# Altium schematic sheet FINAL_VERSION.SchDoc(FINAL_VERSION)
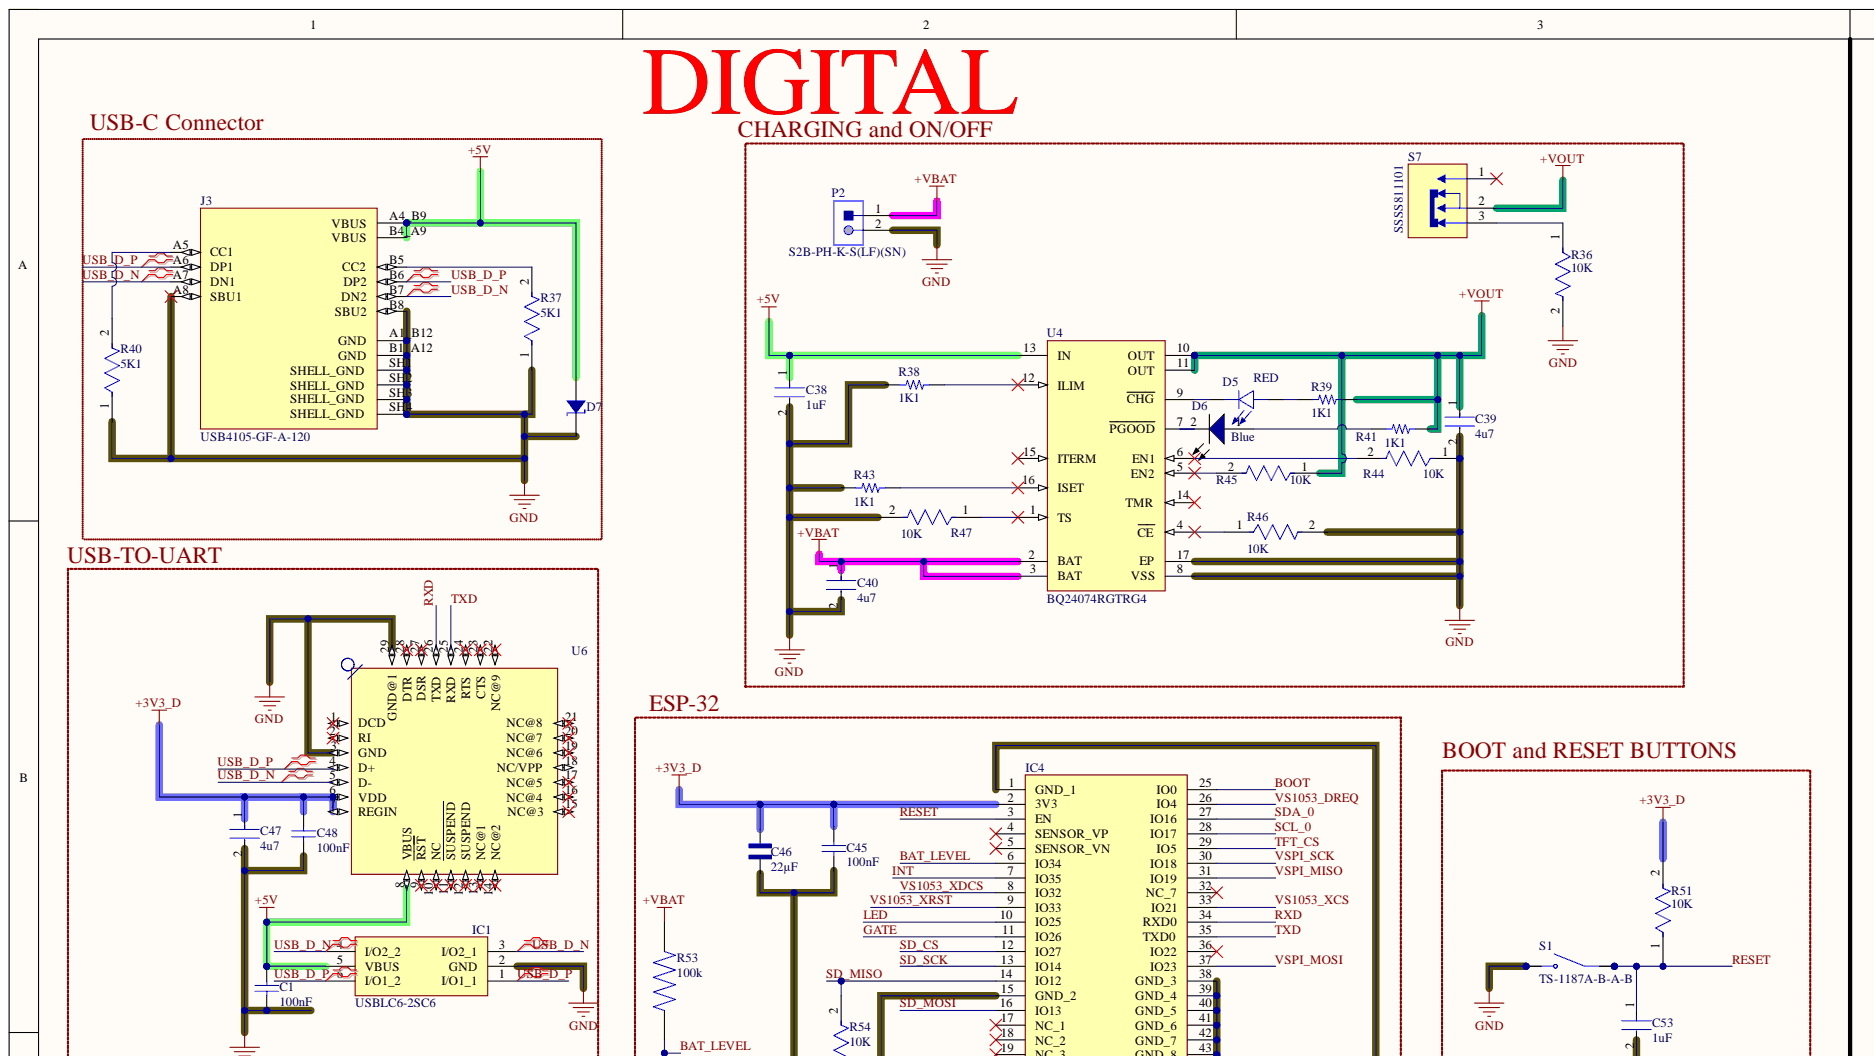
[diagram p0: FINAL_VERSION.SchDoc, top left]
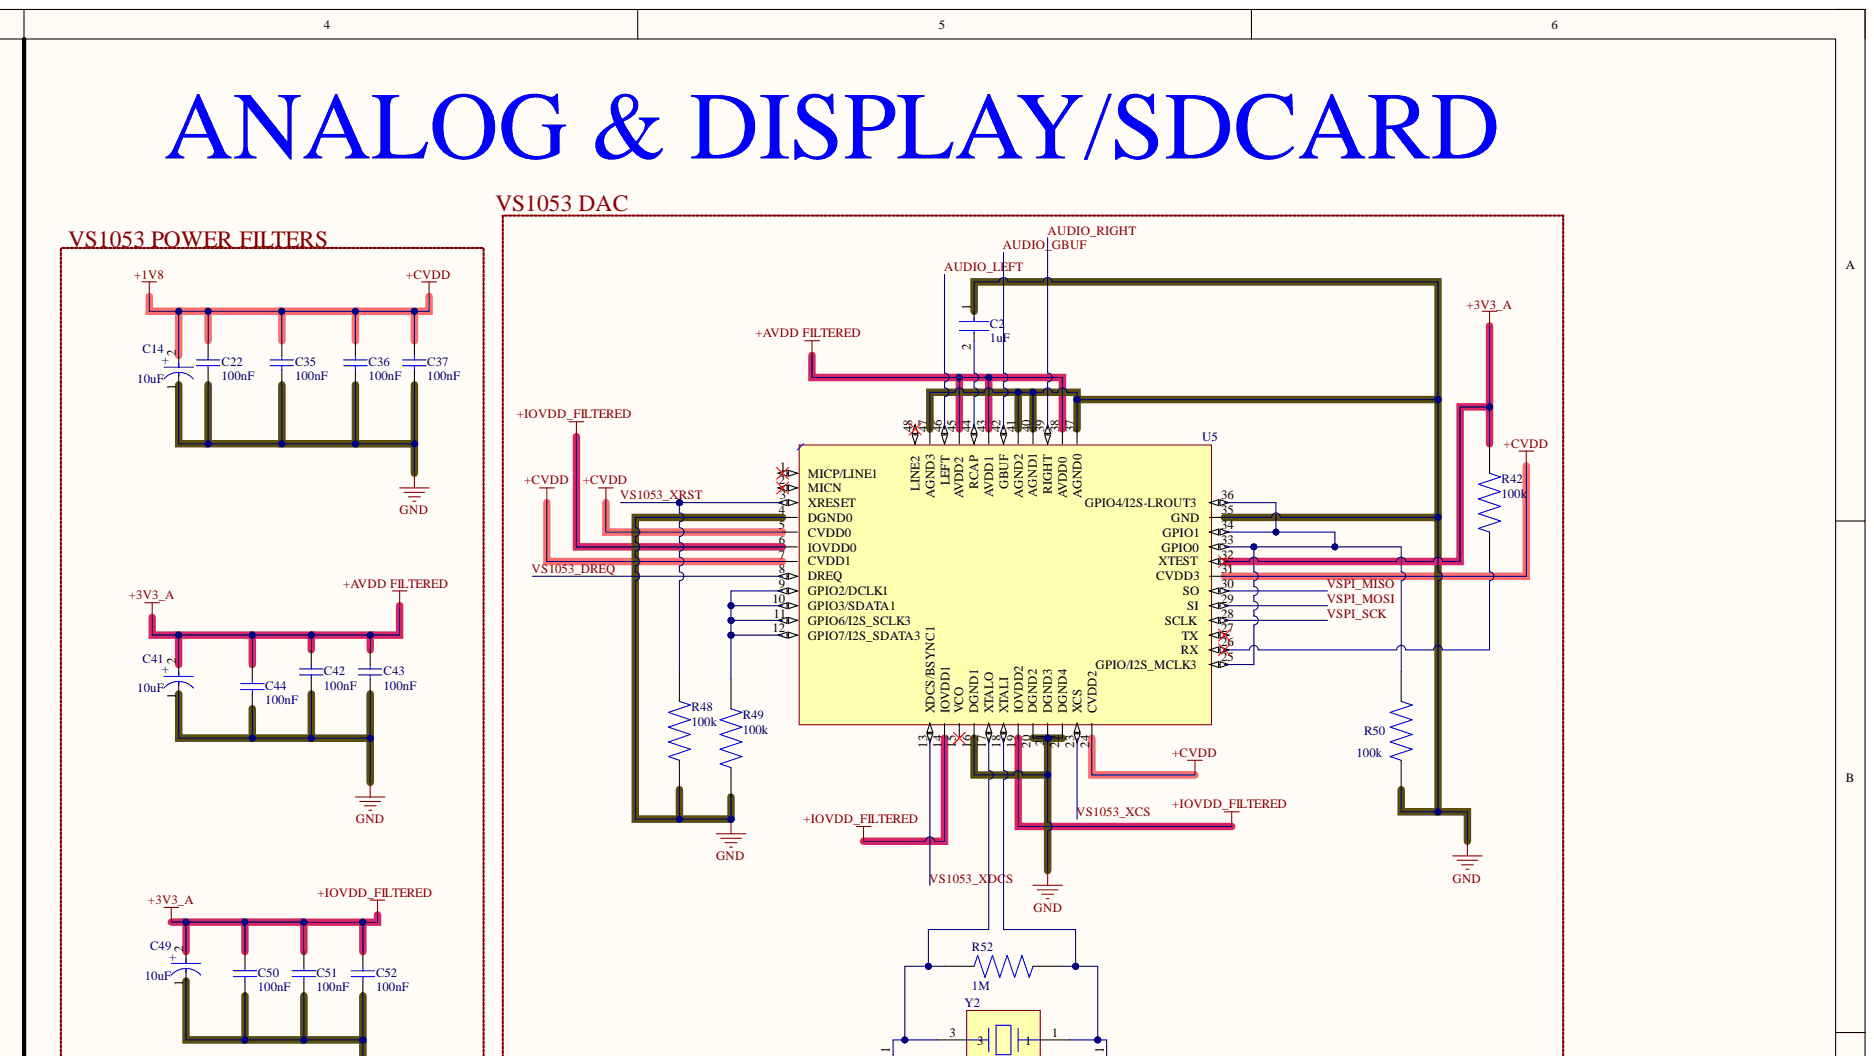
[diagram p1: FINAL_VERSION.SchDoc, top right]
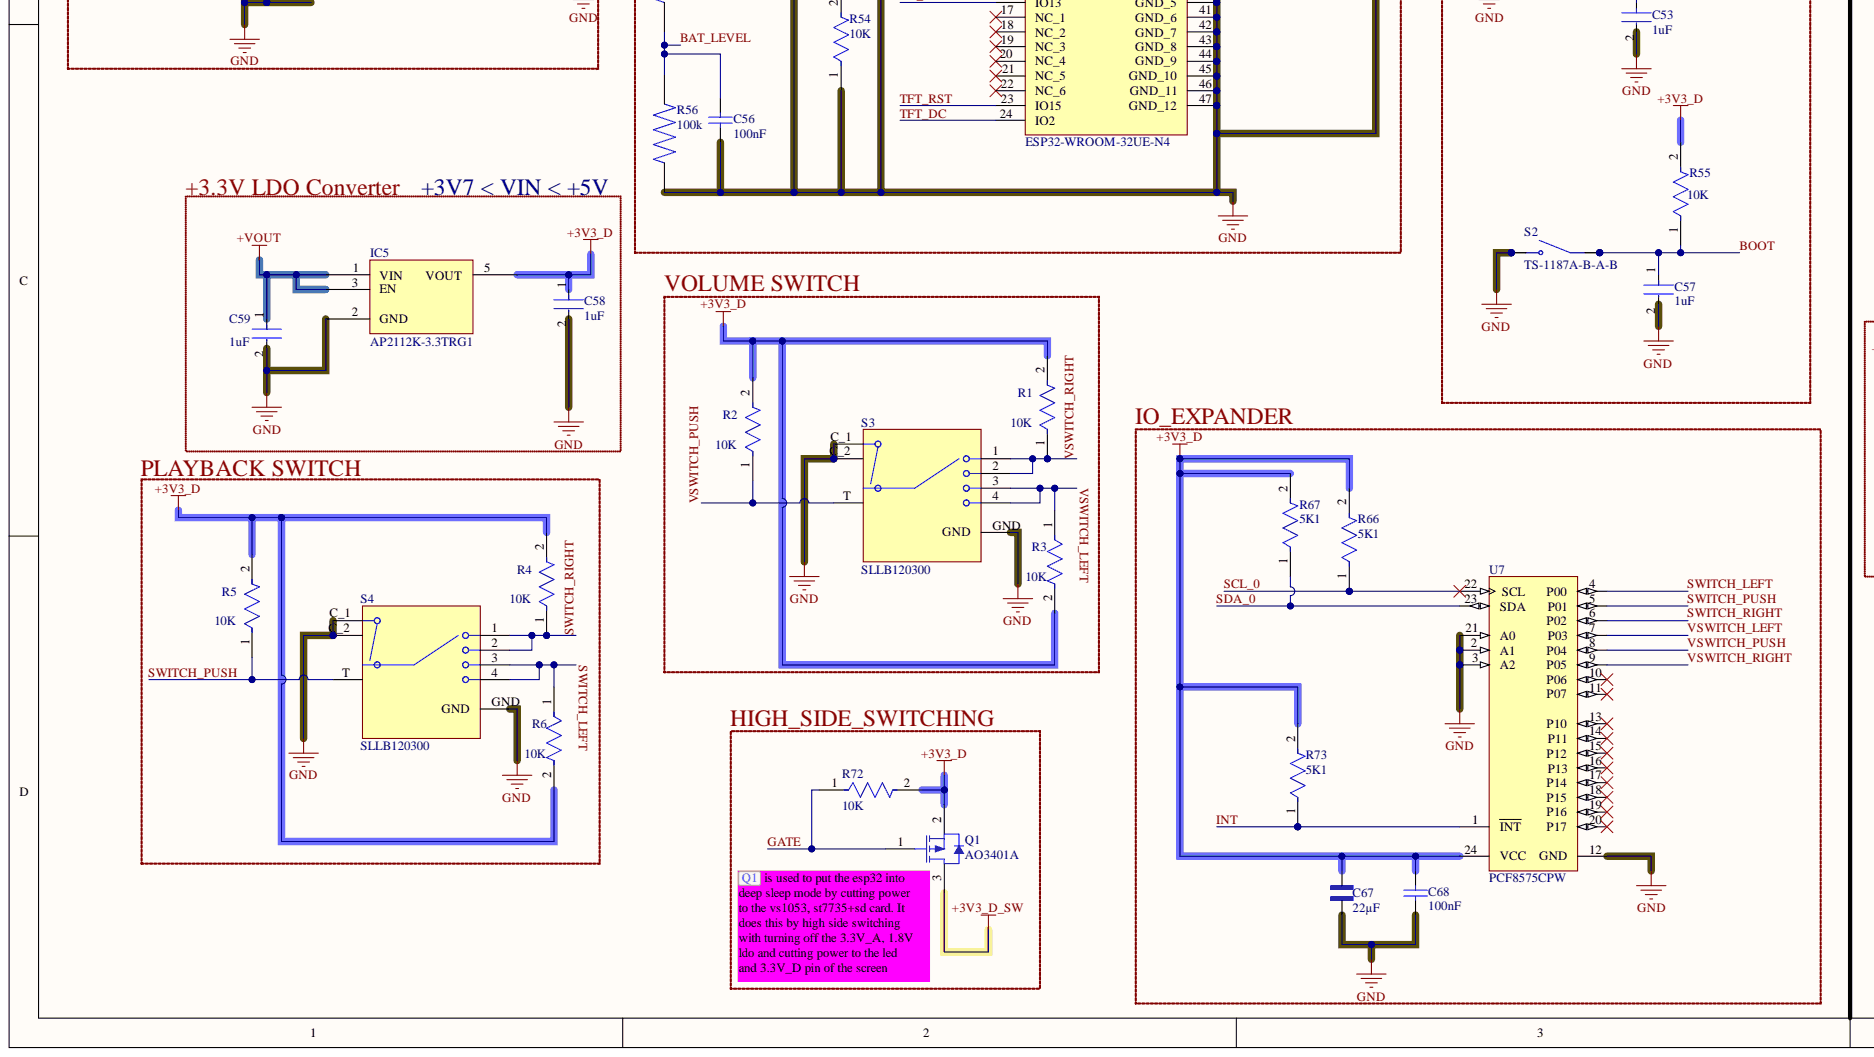
[diagram p2: FINAL_VERSION.SchDoc, bottom left]
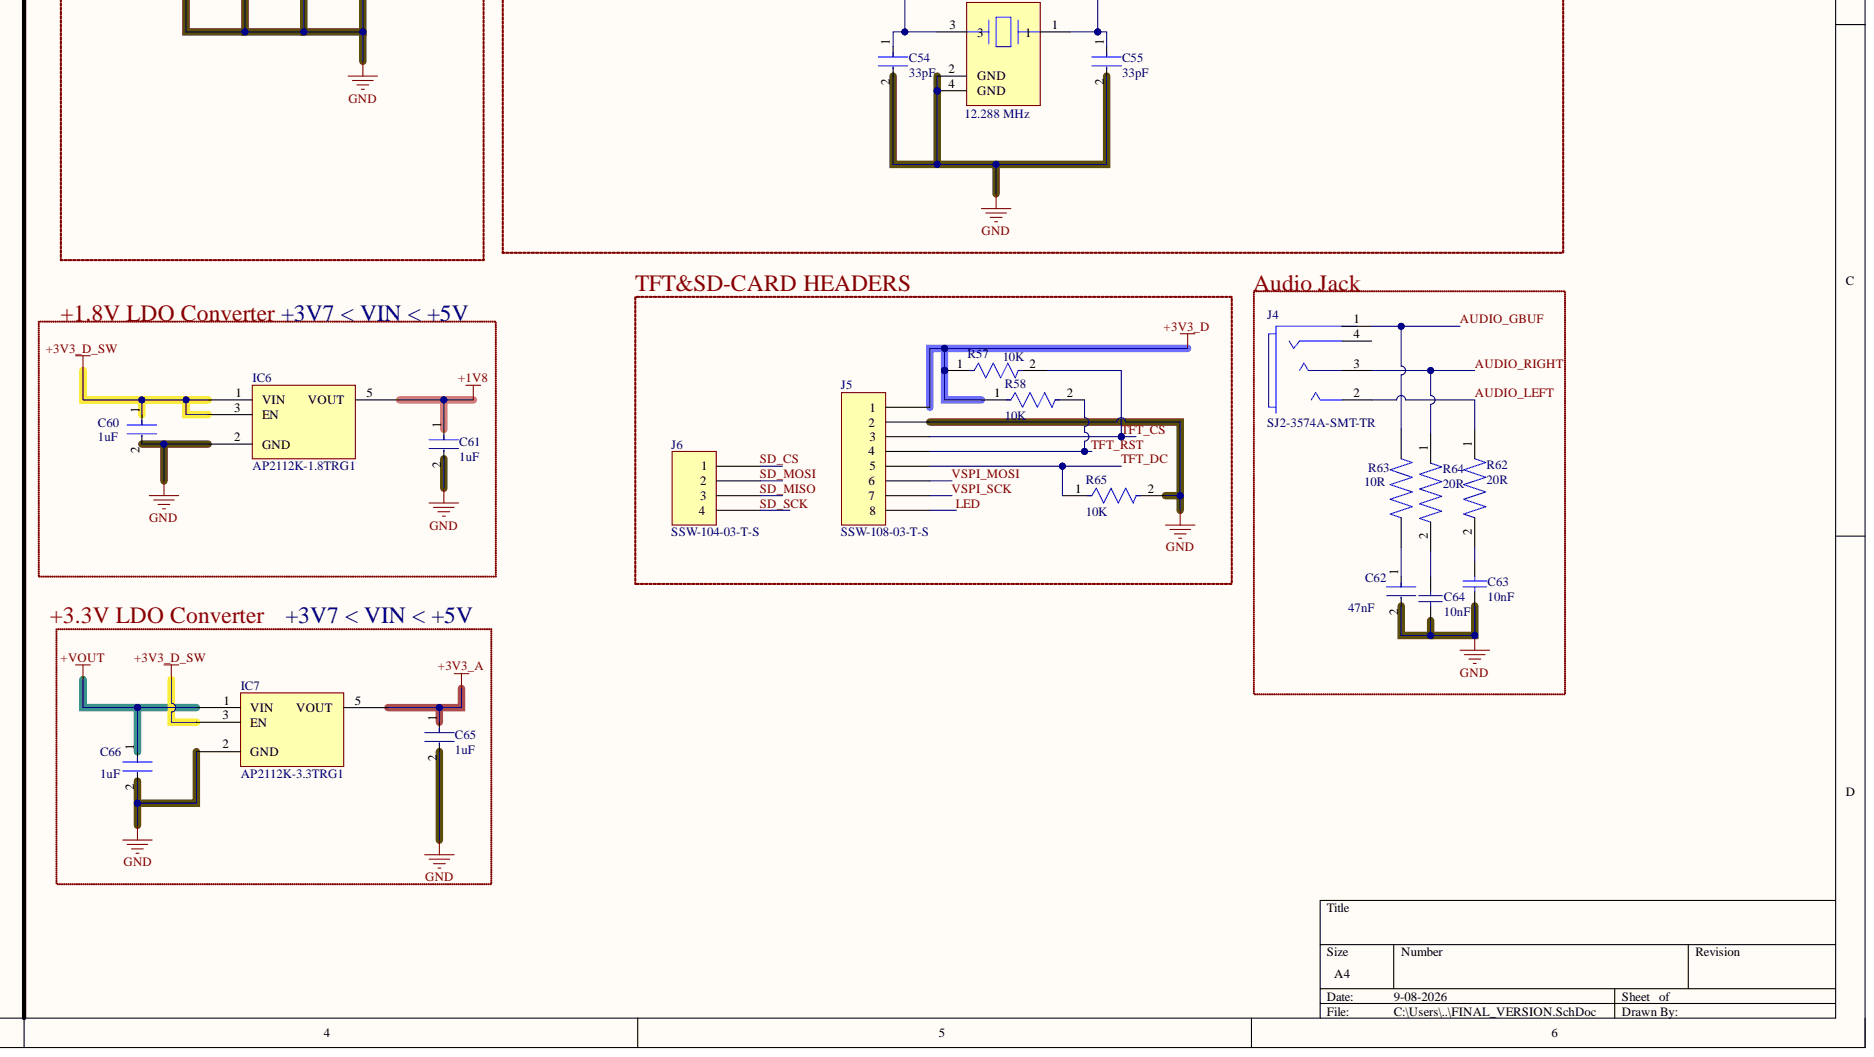
[diagram p3: FINAL_VERSION.SchDoc, bottom right]

## Parts
C1  100nF  [CAPC1005X55X25ML05T10]
C2  1uF  [FP-CL511-IPC_C]
C14  10uF  [FP-TAJA-MFG]
C22  100nF  [CAPC1005X55X25ML05T10]
C35  100nF  [CAPC1005X55X25ML05T10]
C36  100nF  [CAPC1005X55X25ML05T10]
C37  100nF  [CAPC1005X55X25ML05T10]
C38  1uF  [FP-CL511-IPC_C]
C39  4u7  [FP-CL282-IPC_C]
C40  4u7  [FP-CL282-IPC_C]
C41  10uF  [FP-TAJA-MFG]
C42  100nF  [CAPC1005X55X25ML05T10]
C43  100nF  [CAPC1005X55X25ML05T10]
C44  100nF  [CAPC1005X55X25ML05T10]
C45  100nF  [CAPC1005X55X25ML05T10]
C46  value 22µF  [CAPC1608X100N]  (hidden comment: CL10A226MQ8NRNC)
C47  4u7  [FP-CL282-IPC_C]
C48  100nF  [CAPC1005X55X25ML05T10]
C49  10uF  [FP-TAJA-MFG]
C50  100nF  [CAPC1005X55X25ML05T10]
C51  100nF  [CAPC1005X55X25ML05T10]
C52  100nF  [CAPC1005X55X25ML05T10]
C53  1uF  [FP-CL511-IPC_C]
C54  33pF  [FP-CL790-IPC_C]
C55  33pF  [FP-CL790-IPC_C]
C56  100nF  [CAPC1005X55X25ML05T10]
C57  1uF  [FP-CL511-IPC_C]
C58  1uF  [FP-CL511-IPC_C]
C59  1uF  [FP-CL511-IPC_C]
C60  1uF  [FP-CL511-IPC_C]
C61  1uF  [FP-CL511-IPC_C]
C62  47nF  [FP-CL10-IPC_A]
C63  10nF  [CAPC1005X55X25LL05T05]
C64  10nF  [CAPC1005X55X25LL05T05]
C65  1uF  [FP-CL511-IPC_C]
C66  1uF  [FP-CL511-IPC_C]
C67  value 22µF  [CAPC1608X100N]  (hidden comment: CL10A226MQ8NRNC)
C68  100nF  [CAPC1005X55X25ML05T10]
D5  RED  [LED_KT-0603R]
D6  Blue  [LTST-C190TBKT-2_V]
D7  (no value)  [SMF DO-219AB]
IC1  USBLC6-2SC6  [SOT95P280X145-6N]
IC4  ESP32-WROOM-32UE-N4  [ESP32WROOM32UEN4]
IC5  AP2112K-3.3TRG1  [DIODES SOT-23-5 W5]
IC6  AP2112K-1.8TRG1  [DIODES SOT-23-5 W5]
IC7  AP2112K-3.3TRG1  [DIODES SOT-23-5 W5]
J3  USB4105-GF-A-120  [GCT_USB4105-GF-A-120]
J4  SJ2-3574A-SMT-TR  [FP-SJ2-3574A-SMT-TR-MFG]
J5  SSW-108-03-T-S  [SAMTEC SSW-108-03-T-S]
J6  SSW-104-03-T-S  [SAMTEC SSW-104-03-T-S]
P2  S2B-PH-K-S(LF)(SN)  [JST-S2B-PH-K-S_V]
Q1  AO3401A  [AO-SOT-23-3L_V]
R1  10K  [FP-CRCW0402-e3-IPC_B]
R2  10K  [FP-CRCW0402-e3-IPC_B]
R3  10K  [FP-CRCW0402-e3-IPC_B]
R4  10K  [FP-CRCW0402-e3-IPC_B]
R5  10K  [FP-CRCW0402-e3-IPC_B]
R6  10K  [FP-CRCW0402-e3-IPC_B]
R36  10K  [FP-CRCW0402-e3-IPC_B]
R37  5K1  [FP-CRCW0402-e3-IPC_C]
R38  1K1  [RES 0603_1608]
R39  1K1  [RES 0603_1608]
R40  5K1  [FP-CRCW0402-e3-IPC_C]
R41  1K1  [RES 0603_1608]
R42  value 100k  [RESC1005X40N]  (hidden comment: 0402WGF1003TCE)
R43  1K1  [RES 0603_1608]
R44  10K  [FP-CRCW0402-e3-IPC_B]
R45  10K  [FP-CRCW0402-e3-IPC_B]
R46  10K  [FP-CRCW0402-e3-IPC_B]
R47  10K  [FP-CRCW0402-e3-IPC_B]
R48  value 100k  [RESC1005X40N]  (hidden comment: 0402WGF1003TCE)
R49  value 100k  [RESC1005X40N]  (hidden comment: 0402WGF1003TCE)
R50  value 100k  [RESC1005X40N]  (hidden comment: 0402WGF1003TCE)
R51  10K  [FP-CRCW0402-e3-IPC_B]
R52  value 1M  [RESC1005X40N]  (hidden comment: CRCW04021M00FKEDHP)
R53  value 100k  [RESC1005X40N]  (hidden comment: 0402WGF1003TCE)
R54  10K  [FP-CRCW0402-e3-IPC_B]
R55  10K  [FP-CRCW0402-e3-IPC_B]
R56  value 100k  [RESC1005X40N]  (hidden comment: 0402WGF1003TCE)
R57  10K  [FP-CRCW0402-e3-IPC_B]
R58  10K  [FP-CRCW0402-e3-IPC_B]
R62  value 20R  [RESC1608X55N]  (hidden comment: 0603WAF200JT5E)
R63  value 10R  [RESC1005X40N]  (hidden comment: 0402WGF100JTCE)
R64  value 20R  [RESC1608X55N]  (hidden comment: 0603WAF200JT5E)
R65  10K  [FP-CRCW0402-e3-IPC_B]
R66  5K1  [FP-CRCW0402-e3-IPC_C]
R67  5K1  [FP-CRCW0402-e3-IPC_C]
R72  10K  [FP-CRCW0402-e3-IPC_B]
R73  5K1  [FP-CRCW0402-e3-IPC_C]
S1  TS-1187A-B-A-B  [SW_TS-1187A-B-A-B]
S2  TS-1187A-B-A-B  [SW_TS-1187A-B-A-B]
S3  SLLB120300  [SW_SLLB120300]
S4  SLLB120300  [SW_SLLB120300]
S7  SSSS811101  [SW_SSSS811101]
U4  BQ24074RGTRG4  [RGT16-1700X1700TP]
U5  (no value)  [LQFP48-0.5-7X7MM]
U6  (no value)  [QFN28G_0.5-5X5MM]
U7  PCF8575CPW  [PW0024A_N]
Y2  12.288 MHz  [FP-ABM8G-MFG]

## Nets
+1V8: C14.2 C22.2 C35.2 C36.2 C37.2 C61.1 IC6.5(VOUT) U5.5(CVDD0) U5.7(CVDD1) U5.24(CVDD2) U5.31(CVDD3)
+3V3_A: C41.2 C42.2 C43.2 C44.2 C49.2 C50.2 C51.2 C52.2 C65.1 IC7.5(VOUT) R42.2 U5.6(IOVDD0) U5.14(IOVDD1) U5.19(IOVDD2) U5.32(XTEST) U5.38 U5.43(AVDD1) U5.45(AVDD2)
+3V3_D: C45.2 C46.2 C47.1 C48.2 C58.1 C67.2 C68.2 IC4.2(3V3) IC5.5(VOUT) J5.1 Q1.2 R1.2 R2.2 R3.2 R4.2 R5.2 R6.2 R51.2 R55.2 R57.1 R58.1 R66.2 R67.2 R72.2 R73.2 U6.6(VDD) U6.7(REGIN) U7.24(VCC)
+3V3_D_SW: C60.1 IC6.1(VIN) IC6.3(EN) IC7.3(EN) Q1.3
+5V: C1.2 C38.1 D7.1 IC1.5(VBUS) J3.A4_B9(VBUS) J3.B4_A9(VBUS) U4.13(IN) U6.8(VBUS)
+VBAT: C40.1 P2.1 R53.2 U4.2(BAT) U4.3(BAT)
+VOUT: C39.1 C59.1 C66.1 IC5.1(VIN) IC5.3(EN) IC7.1(VIN) R39.2 R41.2 R45.1 S7.2 U4.10(OUT) U4.11(OUT)
GND: C1.1 C2.1 C14.1 C22.1 C35.1 C36.1 C37.1 C38.2 C39.2 C40.2 C41.1 C42.1 C43.1 C44.1 C45.1 C46.1 C47.2 C48.1 C49.1 C50.1 C51.1 C52.1 C53.2 C54.2 C55.2 C56.1 C57.2 C58.2 C59.2 C60.2 C61.2 C62.2 C63.1 C64.1 C65.2 C66.2 C67.1 C68.1 D7.2 IC1.2(GND) IC4.1(GND_1) IC4.15(GND_2) IC4.38(GND_3) IC4.39(GND_4) IC4.40(GND_5) IC4.41(GND_6) IC4.42(GND_7) IC4.43(GND_8) IC4.44(GND_9) IC4.45(GND_10) IC4.46(GND_11) IC4.47(GND_12) IC5.2(GND) IC6.2(GND) IC7.2(GND) J3.A1_B12(GND) J3.A8(SBU1) J3.B1_A12(GND) J3.B8(SBU2) J3.SH1(SHELL_GND) J3.SH2(SHELL_GND) J3.SH3(SHELL_GND) J3.SH4(SHELL_GND) J5.2 P2.2 R36.2 R37.1 R38.1 R40.1 R43.1 R44.1 R46.2 R47.2 R48.1 R49.1 R50.1 R54.1 R56.1 R65.2 S1.C S1.D S2.C S2.D S3.C_1 S3.C_2 S3.GND S4.C_1 S4.C_2 S4.GND U4.8(VSS) U4.17(EP) U5.4(DGND0) U5.16(DGND1) U5.20(DGND2) U5.21(DGND3) U5.22 U5.35(GND) U5.37(AGND0) U5.40(AGND1) U5.41(AGND2) U5.47(AGND3) U6.3(GND) U6.29(GND@1) U7.2(A1) U7.3(A2) U7.12(GND) U7.21(A0) Y2.2(GND) Y2.4(GND)
AUDIO_GBUF: J4.1 R63.2 U5.42(GBUF)
AUDIO_LEFT: J4.2 R62.1 U5.46(LEFT)
AUDIO_RIGHT: J4.3 R64.1 U5.39(RIGHT)
BAT_LEVEL: C56.2 IC4.6(IO34) R53.1 R56.2
BOOT: C57.1 IC4.25(IO0) R55.1 S2.A S2.B
GATE: IC4.11(IO26) Q1.1 R72.1
INT: IC4.7(IO35) R73.1 U7.1(INT)
LED: IC4.10(IO25) J5.8
RESET: C53.1 IC4.3(EN) R51.1 S1.A S1.B
RXD: IC4.34(RXD0) U6.26
SCL_0: IC4.28(IO17) R66.1 U7.22(SCL)
SD_CS: IC4.12(IO27) J6.1
SD_MISO: IC4.14(IO12) J6.3 R54.2
SD_MOSI: IC4.16(IO13) J6.2
SD_SCK: IC4.13(IO14) J6.4
SDA_0: IC4.27(IO16) R67.1 U7.23(SDA)
SWITCH_LEFT: R6.1 S4.3 S4.4 U7.4(P00)
SWITCH_PUSH: R5.1 S4.T U7.5(P01)
SWITCH_RIGHT: R4.1 S4.1 S4.2 U7.6(P02)
TFT_CS: IC4.29(IO5) J5.3 R57.2
TFT_DC: IC4.24(IO2) J5.5 R65.1
TFT_RST: IC4.23(IO15) J5.4 R58.2
TXD: IC4.35(TXD0) U6.25(RXD)
USB_D_N: IC1.3(I/O2_1) IC1.4(I/O2_2) J3.A7(DN1) J3.B7(DN2) U6.5(D-)
USB_D_P: IC1.1(I/O1_1) IC1.6(I/O1_2) J3.A6(DP1) J3.B6(DP2) U6.4(D+)
VS1053_DREQ: IC4.26(IO4) U5.8(DREQ)
VS1053_XCS: IC4.33(IO21) U5.23(XCS)
VS1053_XDCS: IC4.8(IO32) U5.13(XDCS/BSYNC1)
VS1053_XRST: IC4.9(IO33) R48.2 U5.3(XRESET)
VSPI_MISO: IC4.31(IO19) U5.30(SO)
VSPI_MOSI: IC4.37(IO23) J5.6 U5.29(SI)
VSPI_SCK: IC4.30(IO18) J5.7 U5.28(SCLK)
VSWITCH_LEFT: R3.1 S3.3 S3.4 U7.7(P03)
VSWITCH_PUSH: R2.1 S3.T U7.8(P04)
VSWITCH_RIGHT: R1.1 S3.1 S3.2 U7.9(P05)
NetC2_2: C2.2 U5.44(RCAP)
NetC54_1: C54.1 R52.1 U5.17(XTALO) Y2.3
NetC55_1: C55.1 R52.2 U5.18(XTALI) Y2.1
NetC62_1: C62.1 R63.1
NetC63_2: C63.2 R62.2
NetC64_2: C64.2 R64.2
NetD5_A: D5.A R39.1
NetD5_C: D5.C U4.9(CHG)
NetD6_1: D6.1 R41.1
NetD6_2: D6.2 U4.7(PGOOD)
NetJ3_A5: J3.A5(CC1) R40.2
NetJ3_B5: J3.B5(CC2) R37.2
NetR36_1: R36.1 S7.3
NetR38_2: R38.2 U4.12(ILIM)
NetR42_1: R42.1 U5.26(RX)
NetR43_2: R43.2 U4.16(ISET)
NetR44_2: R44.2 U4.6(EN1)
NetR45_2: R45.2 U4.5(EN2)
NetR46_1: R46.1 U4.4(CE)
NetR47_1: R47.1 U4.1(TS)
NetR49_2: R49.2 U5.9(GPIO2/DCLK1) U5.10(GPIO3/SDATA1) U5.11(GPIO6/I2S_SCLK3) U5.12(GPIO7/I2S_SDATA3)
NetR50_2: R50.2 U5.25(GPIO/I2S_MCLK3) U5.33(GPIO0) U5.34(GPIO1) U5.36(GPIO4/I2S-LROUT3)

Not connected: IC4 4(SENSOR_VP) 5(SENSOR_VN) 17(NC_1) 18(NC_2) 19(NC_3) 20(NC_4) 21(NC_5) 22(NC_6) 32(NC_7) 36(IO22); J4 4; S7 1; U4 14(TMR) 15(ITERM); U5 1(MICP/LINE1) 2(MICN) 15(VCO) 27(TX) 48(LINE2); U6 1(DCD) 2(RI) 9(RST) 10 11(SUSPEND) 12(SUSPEND) 13(NC@1) 14(NC@2) 15(NC@3) 16(NC@4) 17(NC@5) 18(NC/VPP) 19(NC@6) 20(NC@7) 21(NC@8) 22(NC@9) 23(CTS) 24(RTS) 27(DSR) 28(DTR); U7 10(P06) 11(P07) 13(P10) 14(P11) 15(P12) 16(P13) 17(P14) 18(P15) 19(P16) 20(P17)

## Library data of the parts
C1 C22 C35-C37 C42-C45 C48 C50-C52 C56 C68 = CMP-2008-02013-1: Manufacturer: Vitramon; Part Number: VJ0402G104KXQCW1BC; Component Kind: Standard; Library Name: Altium Content Vault
C2 C38 C53 C57-C61 C65 C66 = CMP-13271-001936-1: Cap Ceramic 1uF 10V X5R ±10% SMD 0402 +85°C Paper T/R; Capacitance: 1uF; Case Code (Imperial): 0402; Case Code (Metric): 1005; Case/Package: 0402; Component Kind: Standard; Contact Plating: Tin; Depth: 0.5mm; Dielectric: X5R; Height: 0.5mm; Length: 1mm; Library Name: Altium Content Vault; Material: Ceramic; Max Operating Temperature: 85°C; Min Operating Temperature: -55°C; Mount: Surface Mount; Package Quantity: 1; Packaging: Tape and Reel; Radiation Hardening: No; Thickness: 0.022inch; Tolerance: 10%; Voltage Rating: 10V; Width: 0.02inch
C14 C41 C49 = CMP-1661-00004-2: 10uF 16V  ±10% 3Ω 1206  SMD Tantalum Capacitor; Dissipation Factor: 8%; ESR (Equivalent Series Resistance): 3R; Leakage Current: 1.6uA; Polarity: Polar; Capacitance: 10uF; Case Code (Imperial): 1206; Case Code (Metric): 3216; Case/Package: 1206; Component Kind: Standard; Depth: 1.6mm; Dielectric Material: Tantalum; Features: General Purpose; Height: 1.6mm; Height - Seated (Max): 0.071inch; Lead Free: Lead Free; Lead Pitch: 1.1mm; Length: 3.2mm; Library Name: Altium Content Vault; Max Operating Temperature: 125°C; Min Operating Temperature: -55°C; Mount: Surface Mount; Number of Pins: 2; Package Quantity: 1; Packaging: Tape and Reel; Radiation Hardening: No; REACH SVHC: No SVHC; Termination: SMD/SMT; Tolerance: 10%; Voltage: 16V; Voltage Rating: 16V; Voltage Rating (DC): 16V; Width: 1.6mm
C39 C40 C47 = CMP-13271-000548-1: Cap Ceramic 4.7uF 10V X5R ±20% SMD 0402 +85°C Paper T/R; Capacitance: 4.7uF; Case Code (Imperial): 0402; Case Code (Metric): 1005; Case/Package: 0402; Component Kind: Standard; Dielectric: X5R; Lead Free: Lead Free; Length: 0.039inch; Library Name: Altium Content Vault; Max Operating Temperature: 85°C; Min Operating Temperature: -55°C; Mount: Surface Mount; Package Quantity: 10000; Packaging: Tape and Reel; Thickness: 0.022inch; Tolerance: 20%; Voltage Rating: 10V; Width: 0.02inch
C46 C67 = CMP-001-00086-1: CL10 Series 22 uF 6.3 V Â±20 % Tolerance X5R SMT Multilayer Ceramic Capacitor; MF: Samsung Electro-Mechanics; Case/Package: SMD-2 Samsung; Check_prices: https://www.snapeda.com/parts/CL10A226MQ8NRNC/Samsung/view-part/?ref=eda; Component Kind: Standard; Component Type: Standard; Design Item ID: CL10A226MQ8NRNC; MP: CL10A226MQ8NRNC; Pins: 2; SnapEDA_Link: https://www.snapeda.com/parts/CL10A226MQ8NRNC/Samsung/view-part/?ref=snap; Source: CL10A226MQ8NRNC.SchLib; Library Name: Dhruvil Patel
C54 C55 = CMP-13271-001471-1: Cap Ceramic 33pF 50V C0G ±5% SMD 0402 +125°C Paper T/R; Temperature Coefficient: 300ppm/°C; Capacitance: 33pF; Case Code (Imperial): 0402; Case Code (Metric): 1005; Case/Package: 0402; Component Kind: Standard; Contact Plating: Tin; Depth: 0.5mm; Dielectric: C0G; Height: 0.5mm; Lead Free: Lead Free; Length: 1mm; Library Name: Altium Content Vault; Material: Ceramic; Max Operating Temperature: 125°C; Min Operating Temperature: -55°C; Mount: Surface Mount; Package Quantity: 1; Packaging: Tape and Reel; Radiation Hardening: No; Thickness: 0.022inch; Tolerance: 5%; Voltage: 50V; Voltage Rating: 50V; Voltage Rating (DC): 50V; Width: 0.02inch
C62 = CMP-13271-001056-1: Cap Ceramic 47nF 50V X7R ±10% Pad SMD 0603 +125°C T/R; Dielectric: Y5V; Capacitance: 47nF; Case Code (Imperial): 0603; Case Code (Metric): 1608; Case/Package: 0603; Component Kind: Standard; Contact Plating: Tin; Depth: 0.8mm; Height: 0.8mm; Lead Free: Lead Free; Length: 1.6mm; Library Name: Altium Content Vault; Material: Ceramic; Max Operating Temperature: 125°C; Min Operating Temperature: -55°C; Mount: Surface Mount; Package Quantity: 4000; Packaging: Tape and Reel; Radiation Hardening: No; Thickness: 0.035inch; Tolerance: 10%; Voltage: 50V; Voltage Rating: 50V; Voltage Rating (DC): 50V; Width: 0.031inch
C63 C64 = CMP-1034-01870-2: Manufacturer: Vitramon; Part Number: VJ0402Y103JXQCW1BC; Component Kind: Standard; Library Name: Altium Content Vault
D5 = CMP-004-00031-1: Red 0603 Light Emitting Diodes; MF: Hubei KENTO Elec; MP: KT-0603R; Case/Package: Package; Check_prices: https://www.snapeda.com/parts/KT-0603R/Hubei+KENTO+Elec/view-part/?ref=eda; Component Kind: Standard; Component Type: Standard; Design Item ID: KT-0603R; Pins: 2; SnapEDA_Link: https://www.snapeda.com/parts/KT-0603R/Hubei+KENTO+Elec/view-part/?ref=snap; Source: KT-0603R.SchLib; Value: RED; Library Name: Dhruvil Patel
D6 = CMP-007-00026-2: LED Uni-Color Blue, 76 mW, 20 mA, -20 to 80 degC, 2-Pin SMD, RoHS, Tape and Reel; Manufacturer: Lite-On; Forward Current: 20mA; Forward Voltage: 2.8V; Lens Style: Diffused; Luminous Intensity: 28mcd; Nominal Vf: 3.3V; Viewing Angle: 130degrees; Case/Package: 0603; Colour: Blue; Component Kind: Standard; Datasheet URL: http://optoelectronics.liteon.com/upload/download/DS-22-99-0224/S_110_LTST-C190TBKT(0630).pdf; Datasheet Version: Rev. K, 08/2011; Illumination Colour: Blue; Manufacturer URL: http://www.us.liteon.com/; Max Operating Temperature: 80°C; Min Operating Temperature: -20°C; Mounting Technology: SM; Package Description: 2-Pin Surface Mount Device, Body 1.6 x 0.8 mm; Package Quantity: 3000; Package Reference: C190TBKT-2; Packaging: TapeandReel; Pins: 2; RoHS Compliant: True; Library Name: Dhruvil Patel
D7 = VTVS5V0ASMF-M3-08: TVS DIODE 5V 8.9V DO219AB; Manufacturer: Vishay Semiconductor Diodes Division; Capacitance @ Frequency: 2095pF @ 1MHz; Current - Peak Pulse (10/1000µs): 42.95A; Database Table Name: Diodes - TVS; Power - Peak Pulse: 400W; Power Line Protection: No; Type: Zener; Unidirectional Channels: 1; Voltage - Breakdown (Min): 6.4V; Applications: General Purpose; Component Kind: Standard; ComponentLink1Description: Datasheet; ComponentLink1URL: http://www.vishay.com/docs/85891/vtvs3v3asmf.pdf; ComponentLink2Description: DigiKey Link; ComponentLink2URL: http://digikey.com/product-detail/en/vishay-semiconductor-diodes-division/VTVS5V0ASMF-M3-08/VTVS5V0ASMF-M3-08GICT-ND/7056003; LastUpdated: 2026-04-05 9:00:08 PM; Library Name: Celestial Altium Library - altium_library.DbLib; Lifecycle Status: Active; Minimum Order: 1; Mounting Type: Surface Mount; Operating Temperature: -55°C ~ 175°C (TJ); Package / Case: DO-219AB; Packaging: TapeAndReel; Part Number: VTVS5V0ASMF-M3-08; Part Status: Active; PartId: 5492; Price: 0.11; Series: TransZorb®; Supplier 1: DigiKey; Supplier Device Package: DO-219AB (SMF); Supplier Part Number 1: VTVS5V0ASMF-M3-08GICT-ND; Voltage - Clamping (Max) @ Ipp: 8.9V; Voltage - Reverse Standoff (Typ): 5V
IC1 = USBLC6-2SC6: Integrated Circuit; Manufacturer_Name: STMicroelectronics; Arrow Part Number: USBLC6-2SC6; Arrow Price/Stock: https://www.arrow.com/en/products/usblc6-2sc6/stmicroelectronics.html?utm_currency=USD&region=nac; Component Kind: Standard; Datasheet Link: https://www.st.com/resource/en/datasheet/usblc6-2.pdf; Height: 1.45mm; Manufacturer_Part_Number: USBLC6-2SC6; Mouser Part Number: 511-USBLC6-2SC6; Mouser Price/Stock: https://www.mouser.co.uk/ProductDetail/STMicroelectronics/USBLC6-2SC6?qs=po45yt2pPpu%2FhNIlwQdTlg%3D%3D
IC4 = ESP32-WROOM-32UE-N4: Integrated Circuit; Manufacturer_Name: Espressif Systems; Component Kind: Standard; Datasheet Link: https://www.espressif.com/sites/default/files/documentation/esp32-wroom-32e_esp32-wroom-32ue_datasheet_en.pdf; Height: 3.35mm; Library Name: SamacSys.SchLib; Manufacturer_Part_Number: ESP32-WROOM-32UE-N4; Mouser Part Number: 356-ESP32WRM32UE32UH; Mouser Price/Stock: https://www.mouser.co.uk/ProductDetail/Espressif-Systems/ESP32-WROOM-32UE-N4?qs=Li%252BoUPsLEnsnnWrvUBU2ZA%3D%3D
IC5 IC7 = AP2112K-3.3TRG1: IC REG LINEAR 3.3V 600MA SOT-25; Manufacturer: Diodes Incorporated; Control Features: Enable; Current - Quiescent (Iq): 80 µA; Database Table Name: Voltage Regulators - Linear; Number of Regulators: 1; Output Configuration: Positive; Output Type: Fixed; Protection Features: Over Current, Over Temperature; PSRR: 65dB (100Hz ~ 1kHz); Voltage - Input (Max): 6V; Voltage Dropout (Max): 0.4V @ 600mA; Component Kind: Standard; ComponentLink1Description: Datasheet; ComponentLink1URL: https://www.diodes.com/assets/Datasheets/AP2112.pdf; ComponentLink2Description: DigiKey Link; ComponentLink2URL: https://www.digikey.com/en/products/detail/diodes-incorporated/AP2112K-3-3TRG1/4470746; Current - Output: 600mA; LastUpdated: 2026-04-05 8:55:48 PM; Library Name: Celestial Altium Library - altium_library.DbLib; Lifecycle Status: Active; Minimum Order: 1; Mounting Type: Surface Mount; Operating Temperature: -40°C ~ 85°C; Package / Case: SC-74A, SOT-753; Packaging: TapeAndReel; Part Number: AP2112K-3.3TRG1; Part Status: Active; Price: 0.08; Series: -; Supplier 1: DigiKey; Supplier Device Package: SOT-25; Supplier Part Number 1: AP2112K-3.3TRG1DICT-ND; Voltage - Output (Min/Fixed): 3.3V
IC6 = AP2112K-1.8TRG1: IC REG LINEAR 1.8V 600MA SOT-25; Manufacturer: Diodes Incorporated; Control Features: Enable; Current - Quiescent (Iq): 80 µA; Database Table Name: Voltage Regulators - Linear; Number of Regulators: 1; Output Configuration: Positive; Output Type: Fixed; Protection Features: Over Current, Over Temperature; PSRR: 65dB (100Hz ~ 1kHz); Voltage - Input (Max): 6V; Voltage Dropout (Max): 0.7V @ 600mA; Component Kind: Standard; ComponentLink1Description: Datasheet; ComponentLink1URL: https://www.diodes.com/assets/Datasheets/AP2112.pdf; ComponentLink2Description: DigiKey Link; ComponentLink2URL: https://www.digikey.com/en/products/detail/diodes-incorporated/AP2112K-1-8TRG1/4470745; Current - Output: 600mA; LastUpdated: 2026-04-05 8:55:48 PM; Library Name: Celestial Altium Library - altium_library.DbLib; Lifecycle Status: Active; Minimum Order: 1; Mounting Type: Surface Mount; Operating Temperature: -40°C ~ 85°C; Package / Case: SC-74A, SOT-753; Packaging: TapeAndReel; Part Number: AP2112K-1.8TRG1; Part Status: Active; Price: 0.08; Series: -; Supplier 1: DigiKey; Supplier Device Package: SOT-25; Supplier Part Number 1: AP2112K-1.8TRG1DICT-ND; Voltage - Output (Min/Fixed): 1.8V
J3 = CMP-002-00071-1: USB CONN, 2.0 TYPE C, RCPT, 16POS, SMT; USB Connector Type:USB Type C; USB Standard:USB 2.0; Gender:Receptacle; No. of Positions:16Positions; Connector Mounting:Surface Mount, Through Hole Mount; Orientation:Right Angle RoHS Compliant: Yes; MF: Global Connector Technology; MP: USB4105GFA120; Case/Package: None; Check_prices: https://www.snapeda.com/parts/USB4105GFA120/Global+Connector+Technology/view-part/?ref=eda; Component Kind: Standard; Component Type: Standard; Design Item ID: USB4105-GF-A-120; Pins: 16; SnapEDA_Link: https://www.snapeda.com/parts/USB4105GFA120/Global+Connector+Technology/view-part/?ref=snap; Source: USB4105-GF-A-120.SchLib; Library Name: Dhruvil Patel
J4 = CMP-14818-000001-1: AUDIO JACK, 3.5 MM, RT, 4 CONDUC; Manufacturer: CUI; Conn Orientation: Horizontal; Current Rating: 1A; Number Of Contacts: 4; Voltage Rating: 12V; Automotive: No; Category: Conn; Component Kind: Standard; ComponentLink2Description: Datasheet; ComponentLink2URL: https://www.cui.com/product/resource/sj2-357x-smt.pdf; Contact Material: Silver; Device Class L1: Connectors; Device Class L2: Audio / Video Connectors; Device Class L3: unset; Digikey Description: AUDIO JACK, 3.5 MM, RT, 4 CONDUC; Height: 5.3mm; Lead Free: Yes; Library Name: Altium Content Vault; Manufacturer Part Number: SJ2-3574A-SMT-TR; Octopart: https://octopart.com/sj2-3574a-smt-tr-cui-77838840; Package: SJ2-3574A-SMT-TR; RoHS: TRUE; Temperature: -25°C to +85°C; Temperature Range High: +85°C; Temperature Range Low: -25°C
J5 = SSW-108-03-T-S: CONN RCPT 8POS 0.1 TIN PCB; Manufacturer: Samtec Inc.; Connector Type: Receptacle; Contact Length - Post: 0.394" (10.00mm); Current Rating (Amps): 5.7A per Contact; Database Table Name: Connectors - Rectangular Headers Female Sockets; Material Flammability Rating: UL94 V-0; Number of Positions: 8; Number of Positions Loaded: All; Pitch - Mating: 0.100" (2.54mm); Style: Board to Board or Cable; Component Kind: Standard; ComponentLink1Description: Datasheet; ComponentLink1URL: http://suddendocs.samtec.com/catalog_english/ssw_th.pdf; ComponentLink2Description: DigiKey Link; ComponentLink2URL: https://www.digikey.com/en/products/detail/samtec-inc/SSW-108-03-T-S/1790200; Contact Finish - Mating: Tin; Contact Finish - Post: Tin; Contact Type: Forked; Fastening Type: Push-Pull; Insulation Color: Black; Insulation Height: 0.335" (8.51mm); LastUpdated: 2022-06-21 3:09:52 PM; Library Name: Celestial Altium Library - altium_library.DbLib; Lifecycle Status: Active; Minimum Order: 1; Mounting Type: Through Hole; Number of Rows: 1; Operating Temperature: -55°C ~ 105°C; Packaging: Bulk; Part Number: SSW-108-03-T-S; Part Status: Active; Price: 0.73; Series: SSW; Supplier 1: DigiKey; Supplier Part Number 1: SAM8866-ND; Termination: Solder
J6 = SSW-104-03-T-S: CONN RCPT 4POS 0.1 TIN PCB; Manufacturer: Samtec Inc.; Connector Type: Receptacle; Current Rating (Amps): 5.7A per Contact; Database Table Name: Connectors - Rectangular Headers Female Sockets; Material Flammability Rating: UL94 V-0; Number of Positions: 4; Number of Positions Loaded: All; Style: Board to Board or Cable; Component Kind: Standard; ComponentLink2Description: DigiKey Link; ComponentLink2URL: http://digikey.com/product-detail/en/samtec-inc/SSW-104-03-T-S/SSW-104-03-T-S-ND/7874034; Contact Finish - Mating: Tin; Contact Finish - Post: Tin; Contact Type: Forked; Fastening Type: Push-Pull; Insulation Color: Black; LastUpdated: 2022-06-21 3:08:52 PM; Library Name: Celestial Altium Library - altium_library.DbLib; Lifecycle Status: Active; Minimum Order: 1; Mounting Type: Through Hole; Number of Rows: 1; Operating Temperature: -55°C ~ 105°C; Packaging: Bulk; Part Number: SSW-104-03-T-S; Part Status: Active; Price: 0.83; Series: SSW; Supplier 1: DigiKey; Supplier Part Number 1: SSW-104-03-T-S-ND; Termination: Solder
P2 = CMP-1755-00004-1: Male Header, Pitch 2 mm, 1 x 2 Position, Height 4.8 mm, Tail Length 3.4 mm, -25 to 85 degC, RoHS, Bulk; Capacitance: 10 uF; Case\Package: Radial; Tolerance: 20%; Voltage Rating: 50 V; Component Kind: Standard; ComponentLink1Description: Datasheet; ComponentLink1URL: http://www.jst-mfg.com/product/pdf/eng/ePH.pdf; DatasheetVersion: Dec-12; Diameter: 5 mm; Height: 11 mm; Lead Pitch: 2 mm; Length: 11 mm; Library Name: Altium Content Vault; Max Operating Temperature: 85 degC; Min Operating Temperature: -40 degC; Mount: Through Hole; Package Quantity: 200; PackageDescription: Male Header, Pitch 2 mm, 1 x 2 Position, Height 4.8 mm, Tail Length 3.4 mm; PackageReference: S2B-PH-K-S; Packaging: Bulk; Pins: 2; Radiation Hardening: No; REACH SVHC: No SVHC; RoHSCompliant: true; Voltage Rating (DC): 50 V
Q1 = CMP-2000-05778-1: P-Channel MOSFET, 30 V, 4 A, -55 to 150 degC, 3-Pin SOT-23-3L, RoHS, Tape and Reel; Manufacturer: Alpha and Omega; Channel Mode: Enhancement; Continuous Drain Current: 4A; Drain Efficiency: NotRequired%; Drain-Source On-Res: 0.044ohm; Drain-Source On-Volt: 30V; Frequency (Max): NotRequiredMHz; Gate-Source Voltage (Max): ?12V; Noise Figure: NotRequireddB; Number of  Elements: 1; Output Power (Max): NotRequiredW; Polarity: P; Power Dissipation: 1.4W; Rad Hardened: No; Type: PowerMOSFET; Component Kind: Standard; Datasheet URL: http://www.aosmd.com/res/data_sheets/AO3401A.pdf; Datasheet Version: Rev. 3, 03/2011; Drain Current (Max): 4A; Library Name: Altium Content Vault; Manufacturer URL: http://www.aosmd.com/; Mounting: SurfaceMount; Mounting Technology: SurfaceMount; Operating Temp Range: -55Cto150C; Operating Temperature Classification: Military; Package Description: 3-Pin Small Outline Transistor, Body 2.95 x 1.6 mm, Pitch 0.95 mm; Package Reference: SOT-23-3L; Package Type: SOT-23; Package URL: http://www.aosmd.com/res/packaging_information/SOT-23-3L.pdf; Pin Count: 3; Power Gain: NotRequireddB
R1-R6 R36 R44-R47 R51 R54 R55 R57 R58 R65 R72 = CMP-2002-08136-3: RES Thick Film, 10kΩ, 5%, 0.063W, 200ppm/°C, 0402; Max Power Dissipation: 63mW; Resistance: 10kR; Voltage Rating: 50V; Case Code (Imperial): 0402; Case Code (Metric): 1005; Case/Package: 0402; Component Kind: Standard; Composition: Thick Film; Contact Plating: Tin; Depth: 0.5mm; Features: Automotive AEC-Q200; Height: 0.016inch; Length: 1mm; Library Name: Altium Content Vault; Max Operating Temperature: 155°C; Military Standard: Not; Min Operating Temperature: -55°C; Mount: Surface Mount; Number of Terminations: 2; Package Quantity: 1; Packaging: Tape and Reel; Power Rating: 63mW; Radiation Hardening: No; Temperature Coefficient: 200ppm/°C; Termination: SMD/SMT; Tolerance: 5%; Weight: 2.3E-05oz; Width: 0.5mm
R37 R40 R66 R67 R73 = CMP-2002-00117-2: RES Thick Film, 5.11kΩ, 1%, 0.063W, 100ppm/°C, 0402; Max Power Dissipation: 63mW; Resistance: 5.11kR; Voltage Rating: 50V; Case Code (Imperial): 0402; Case Code (Metric): 1005; Case/Package: 0402; Component Kind: Standard; Composition: Thick Film; Contact Plating: Tin; Depth: 0.5mm; Features: Automotive AEC-Q200; Height: 0.35mm; Lead Free: Lead Free; Length: 1mm; Library Name: Altium Content Vault; Max Operating Temperature: 155°C; Military Standard: Not; Min Operating Temperature: -55°C; Mount: Surface Mount; Number of Pins: 2; Number of Terminations: 2; Package Quantity: 10; Packaging: Tape and Reel; Power Rating: 63mW; Radiation Hardening: No; Temperature Coefficient: 100ppm/°C; Termination: SMD/SMT; Tolerance: 1%; Voltage Rating (DC): 50V; Weight: 2.3E-05oz; Width: 0.02inch
R38 R39 R41 R43 = CRCW06031K10FKEA: RES SMD 1.1K OHM 1% 1/10W 0603; Manufacturer: Vishay Dale; Database Table Name: Resistors - Surface Mount - 0603; Power (Watts): 0.1W, 1/10W; Resistance: 1.1 kOhms; Temperature Coefficient: ±100ppm/°C; Component Kind: Standard; ComponentLink1Description: Datasheet; ComponentLink1URL: http://www.vishay.com/docs/20035/dcrcwe3.pdf; ComponentLink2Description: DigiKey Link; ComponentLink2URL: https://www.digikey.com/en/products/detail/vishay-dale/CRCW06031K10FKEA/1174672; Composition: Thick Film; Device Package: 0603 (1608 Metric); Features: Automotive AEC-Q200; Height - Seated (Max): 0.020" (0.50mm); LastUpdated: 2024-02-09 8:43:25 PM; Library Name: Celestial Altium Library - altium_library.DbLib; Lifecycle Status: Active; Minimum Order: 1; Number of Terminations: 2; Operating Temperature: -55°C ~ 155°C; Package / Case: 0603 (1608 Metric); Packaging: TapeAndReel; Part Number: CRCW06031K10FKEA; Part Status: Active; PartId: 7849; Price: 0.01; Ratings: AEC-Q200; Series: CRCW; Size / Dimension: 0.061" L x 0.033" W (1.55mm x 0.85mm); Supplier 1: DigiKey; Supplier Device Package: 0603; Supplier Part Number 1: 541-1.10KHCT-ND; Tolerance: ±1%; Value: 1K1
R42 R48-R50 R53 R56 = CMP-009-00178-1: 100K Ohm 1/16W Thick Film Resistor 0402 Chip - Surface Mount; MF: Uni-Royal; Case/Package: 0402-2 ROYAL; Check_prices: https://www.snapeda.com/parts/0402WGF1003TCE/Uni-Royal/view-part/?ref=eda; Component Kind: Standard; Component Type: Standard; Design Item ID: 0402WGF1003TCE; MP: 0402WGF1003TCE; Pins: 2; SnapEDA_Link: https://www.snapeda.com/parts/0402WGF1003TCE/Uni-Royal/view-part/?ref=snap; Source: 0402WGF1003TCE.SchLib; Library Name: Dhruvil Patel
R52 = CMP-009-00179-1: Resistor;Thick Film;1 Megohms;0.125 W;1%;SMT;0402;TCR 37 ppm/DegC; MF: Vishay Dale; Case/Package: 1005 Panasonic Electronic Components; Check_prices: https://www.snapeda.com/parts/CRCW04021M00FKEDHP/Vishay+Dale/view-part/?ref=eda; Component Kind: Standard; Component Type: Standard; Design Item ID: CRCW04021M00FKEDHP; MP: CRCW04021M00FKEDHP; Pins: 2; SnapEDA_Link: https://www.snapeda.com/parts/CRCW04021M00FKEDHP/Vishay+Dale/view-part/?ref=snap; Source: CRCW04021M00FKEDHP.SchLib; Library Name: Dhruvil Patel
R62 R64 = CMP-009-00181-1: 20 Ohm 1/10W Thick Film Resistor 0603 Chip - Surface Mount; MF: Uni-Royal; Case/Package: None; Check_prices: https://www.snapeda.com/parts/0603WAF200JT5E/Uni-Royal/view-part/?ref=eda; Component Kind: Standard; Component Type: Standard; Design Item ID: 0603WAF200JT5E; MP: 0603WAF200JT5E; Pins: 2; SnapEDA_Link: https://www.snapeda.com/parts/0603WAF200JT5E/Uni-Royal/view-part/?ref=snap; Source: 0603WAF200JT5E.SchLib; Library Name: Dhruvil Patel
R63 = CMP-009-00180-1: 10 Ohm 1/16W Thick Film Resistor 0402 Chip - Surface Mount; MF: Uni-Royal; Case/Package: None; Check_prices: https://www.snapeda.com/parts/0402WGF100JTCE/Uni-Royal/view-part/?ref=eda; Component Kind: Standard; Component Type: Standard; Design Item ID: 0402WGF100JTCE; MP: 0402WGF100JTCE; Pins: 2; SnapEDA_Link: https://www.snapeda.com/parts/0402WGF100JTCE/Uni-Royal/view-part/?ref=snap; Source: 0402WGF100JTCE.SchLib; Library Name: Dhruvil Patel
S1 S2 = CMP-047-000000-1: SPST 50mA @ 12VDC Top Actuated Round Button 160gf SMD Tactile Switches RoHS; Availability: Unavailable; Component Kind: Standard; Component Type: Standard; Design Item ID: TS-1187A-B-A-B; MP: TS-1187A-B-A-B; Package: None; Pin Count: 4; Price: None; Source: TS-1187A-B-A-B.SchLib; Library Name: Dhruvil Patel
S3 S4 = CMP-011-00013-2: Electromechanical Switch Lever and Push Switch ON OFF SPST 0.01A T/R; MF: ALPS; Case/Package: None; Check_prices: https://www.snapeda.com/parts/SLLB120300/ALPS/view-part/?ref=eda; Component Kind: Standard; Component Type: Standard; Design Item ID: SLLB120300; MP: SLLB120300; Pins: 8; SnapEDA_Link: https://www.snapeda.com/parts/SLLB120300/ALPS/view-part/?ref=snap; Source: SLLB120300.SchLib; Library Name: Dhruvil Patel
S7 = CMP-011-00014-1: Switch Slide SP Side Slide 0.3A 5VDC 10000Cycles Gull Wing SMD T/R; MF: ALPS; Case/Package: None; Check_prices: https://www.snapeda.com/parts/SSSS811101/ALPS/view-part/?ref=eda; Component Kind: Standard; Component Type: Standard; Design Item ID: SSSS811101; MP: SSSS811101; Pins: 3; SnapEDA_Link: https://www.snapeda.com/parts/SSSS811101/ALPS/view-part/?ref=snap; Source: SSSS811101.SchLib; Value: N/A; Library Name: Dhruvil Patel
U4 = CMP-0321-00219-1: USB-Friendly Li-Ion Battery Charger and Power-Path Management IC, 10.5 V OVP, 4.3 V, -40 to 85 degC, 16-pin QFN (RGT), Green (RoHS & no Sb/Br); Manufacturer: Texas Instruments; Lead Acid: N; SubFamily: Battery Charge Management; Vin(Min)(V): 4.3; Code_JEDEC: MO-220; Component Kind: Standard; ComponentLink1Description: Datasheet; ComponentLink1URL: http://www.ti.com/general/docs/lit/getliterature.tsp?genericPartNumber=BQ24074&fileType=pdf; DatasheetVersion: SLUS810G; Eco-Plan: Green (RoHS & no Sb/Br); Li-Ion: N; Li-Polymer: N; Library Name: Altium Content Vault; Mounting Technology: Surface Mount; NiCd: N; NiMH: N; Over Voltage Protection: N; PackageDescription: 16-Pin Plastic Quad Flat No-Lead, 3 x 3 mm Body, 0.5 mm Pitch, 1.7 x 1.7 mm Exposed Pad; PackageReference: RGT16-1700X1700TP; PackageVersion: revH, Oct-2011; PartNumber: BQ24074RGTRG4
U5 = CMP-009-000000-1: VS1053B MP3/WAV/OGG/MIDI Player & Recorder (CODEC) Chip; MF: VLSI; MP: VS1053B-L; Check_prices: https://www.snapeda.com/parts/VS1053B-L/VLSI/view-part/?ref=eda; Component Kind: Standard; Component Type: Standard; Design Item ID: CODECODER-VS1053B(LQFP48); Package: LQFP-48 VLSI; Pin Count: 48; SnapEDA_Link: https://www.snapeda.com/parts/VS1053B-L/VLSI/view-part/?ref=snap; Library Name: Dhruvil Patel; Source: CODECODER-VS1053B(LQFP48).SchLib
U6 = CMP-013-000000-1: USB Bridge, USB to UART USB 2.0 UART Interface 28-QFN (5x5); MF: Silicon; MP: CP2102-GMR; Availability: In Stock; Check_prices: https://www.snapeda.com/parts/CP2102-GMR/Silicon+Labs/view-part/?ref=eda; Component Kind: Standard; Component Type: Standard; Design Item ID: INTERFACE-CP2102-GMR(QFN28); Package: VFQFN-28 Vishay Siliconix; Pin Count: 29; Price: None; Purchase-URL: https://pricing.snapeda.com/search/part/CP2102-GMR/?ref=eda; SnapEDA_Link: https://www.snapeda.com/parts/CP2102-GMR/Silicon+Labs/view-part/?ref=snap; Source: INTERFACE-CP2102-GMR(QFN28).SchLib; Library Name: Dhruvil Patel
U7 = CMP-0314-00372-2: Remote 16-Bit I2C and SMBus I/O Expander with Interrupt Output, 4.5 to 5.5 V, -40 to 85 degC, 24-pin TSSOP (PW), Green (RoHS & no Sb/Br); Manufacturer: Texas Instruments; # of I/Os: 16; fSCLK(Max)(MHz): 0.4; I2C Address: 0100 xxx; Interrupt Output: Yes; Max Frequency(kHz): 400; SubFamily: I2C IO Expander; VCC(Max)(V): 5.5; VCC(Min)(V): 4.5; Voltage Nodes(V): 5; Code_JEDEC: MO-153; Component Kind: Standard; ComponentLink1Description: Datasheet; ComponentLink1URL: http://www.ti.com/general/docs/lit/getliterature.tsp?genericPartNumber=PCF8575C&fileType=pdf; ComponentLink2Description: Package Specification; ComponentLink2URL: http://www.ti.com/litv/pdf/mpds177g; DatasheetVersion: SCPS123E; Eco-Plan: Green (RoHS & no Sb/Br); Library Name: Altium Content Vault; Mounting Technology: Surface Mount; Open Drain I/O Type: Yes; PackageDescription: 24-Pin Thin Small Outline Package 0.65 mm Pitch; PackageReference: PW0024A; PackageVersion: revG, Feb-2011; PartNumber: PCF8575CPW; Reset Input: No; Vcc range(V): 4.5 to 5.5
Y2 = CMP-27762-013733-1: Crystal 12.288MHz ±20ppm (Tol) ±30ppm (Stability) 18pF FUND 80Ω 4-Pin SMD T/R; ESR (Equivalent Series Resistance): 80R; Case/Package: SMD/SMT; Component Kind: Standard; Frequency: 12.288MHz; Frequency Stability: 30ppm; Frequency Tolerance: 20ppm; Height: 1mm; Length: 3.2mm; Library Name: Altium Content Vault; Load Capacitance: 18pF; Max Operating Temperature: 85°C; Min Operating Temperature: -40°C; Mount: Surface Mount; Number of Pins: 4; Package Quantity: 3000; Packaging: Tape and Reel; Series: ABM8G; Series Resistance: 80R; Termination: SMD/SMT; Tolerance: 20ppm; Width: 2.5mm
